# Altium schematic sheet 1-MAIN.SchDoc
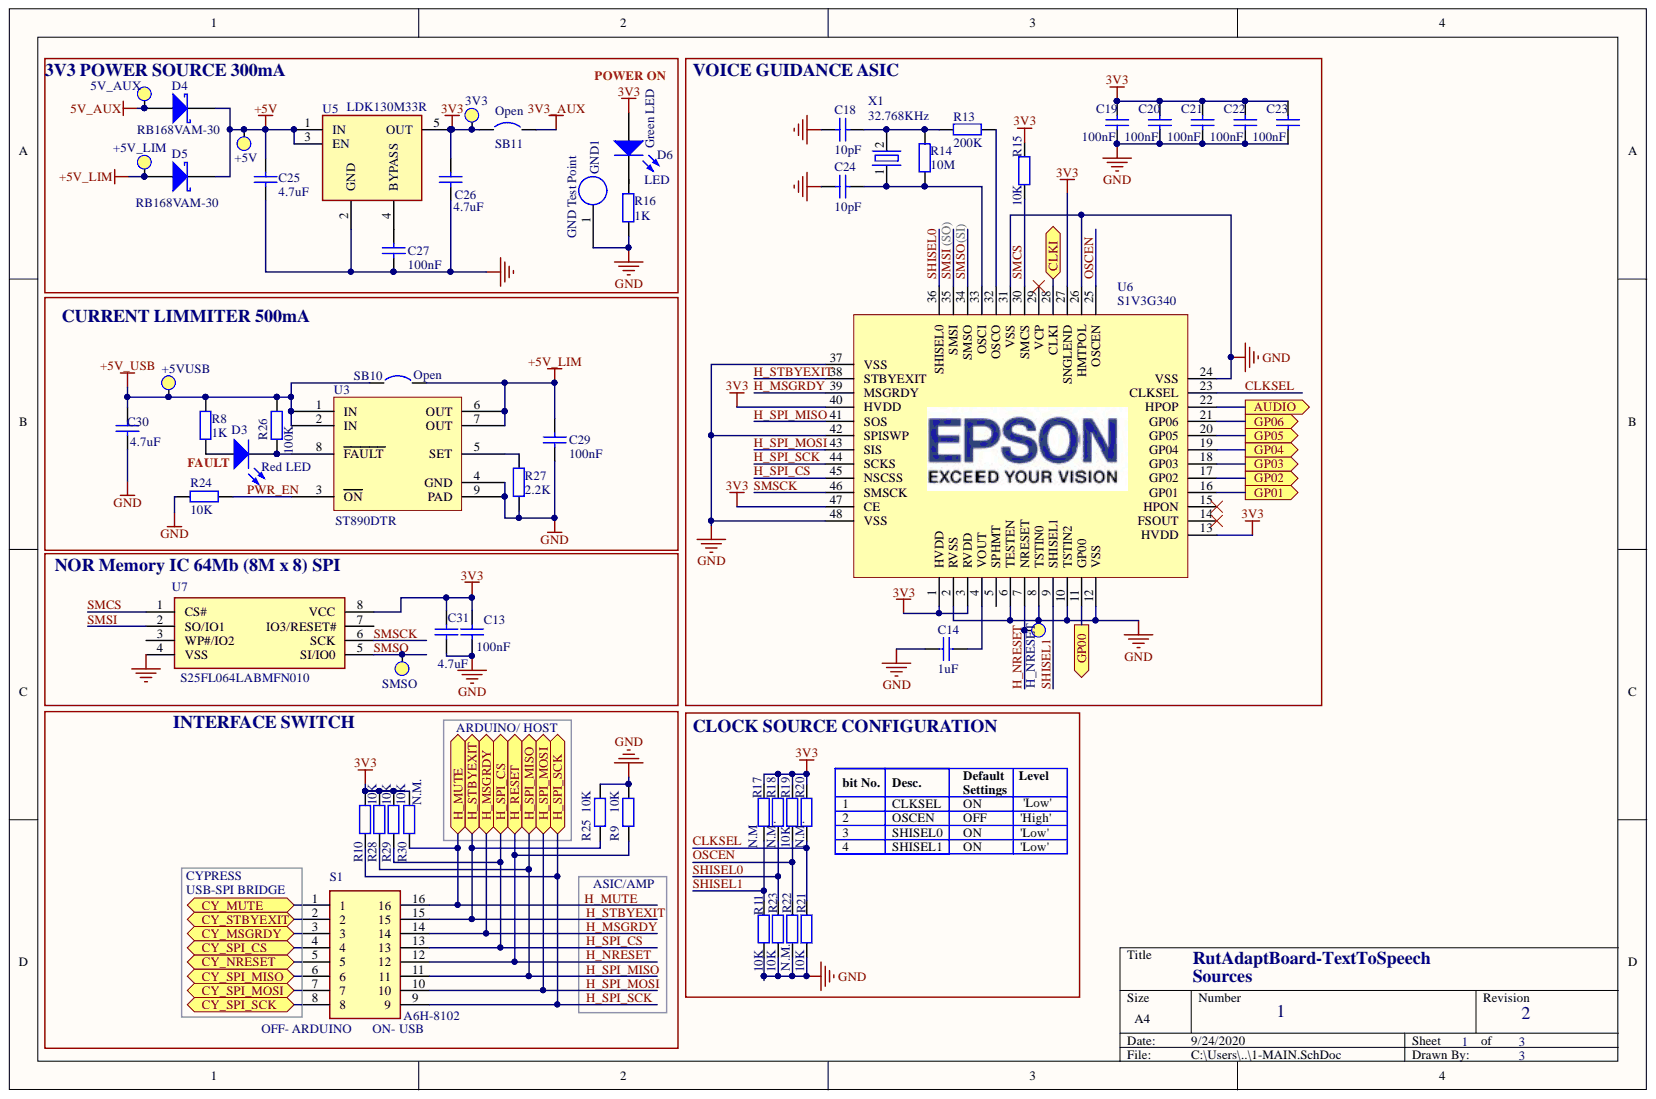
[diagram p0: 1-MAIN.SchDoc]

## Parts
3V3  Test_Point  [TEST_POINT]  (no BOM)
5V_AUX  Test_Point  [TEST_POINT]  (no BOM)
+5V  Test_Point  [TEST_POINT]  (no BOM)
+5V_LIM  Test_Point  [TEST_POINT]  (no BOM)
+5VUSB  Test_Point  [TEST_POINT]  (no BOM)
C13  value 100nF  [C0402]  (hidden comment: Cap Semi)
C14  value 1uF  [C0402]  (hidden comment: Cap Semi)
C18  value 10pF  [C0402]  (hidden comment: Cap Semi)
C19  value 100nF  [C0402]  (hidden comment: Cap Semi)
C20  value 100nF  [C0402]  (hidden comment: Cap Semi)
C21  value 100nF  [C0402]  (hidden comment: Cap Semi)
C22  value 100nF  [C0402]  (hidden comment: Cap Semi)
C23  value 100nF  [C0402]  (hidden comment: Cap Semi)
C24  value 10pF  [C0402]  (hidden comment: Cap Semi)
C25  value 4.7uF  [C0402]  (hidden comment: Cap Semi)
C26  value 4.7uF  [C0402]  (hidden comment: Cap Semi)
C27  value 100nF  [C0402]  (hidden comment: Cap Semi)
C29  value 100nF  [C0402]  (hidden comment: Cap Semi)
C30  value 4.7uF  [C0402]  (hidden comment: Cap Semi)
C31  value 4.7uF  [C0402]  (hidden comment: Cap Semi)
D3  Red LED  [PICOLED]
D4  RB168VAM-30  [SOD323]
D5  RB168VAM-30  [SOD323]
D6  LED  [PICOLED]
GND1  GND Test Point  [5019_keystone]
H_NRESET  Test_Point  [TEST_POINT]  (no BOM)
R8  value 1K  [R0402]  (hidden comment: Res2)
R9  value 10K  [R0402]  (hidden comment: Res2)
R10  value 10K  [R0402]  (hidden comment: Res2)
R11  value 10K  [R0402]  (hidden comment: Res2)
R13  value 200K  [R0402]  (hidden comment: Res2)
R14  value 10M  [R0402]  (hidden comment: Res2)
R15  value 10K  [R0402]  (hidden comment: Res2)
R16  value 1K  [R0402]  (hidden comment: Res2)
R17  value N.M  [R0402 N.M.]  (hidden comment: Res2)  (no BOM)
R18  value N.M.  [R0402 N.M.]  (hidden comment: Res2)  (no BOM)
R19  value 10K  [R0402]  (hidden comment: Res2)
R20  value N.M.  [R0402 N.M.]  (hidden comment: Res2)  (no BOM)
R21  value N.M.  [R0402]  (hidden comment: Res2)
R22  value 10K  [R0402 N.M.]  (hidden comment: Res2)  (no BOM)
R23  value 10K  [R0402]  (hidden comment: Res2)
R24  value 10K  [R0402]  (hidden comment: Res2)
R25  value 10K  [R0402]  (hidden comment: Res2)
R26  value 100K  [R0402]  (hidden comment: Res2)
R27  value 2.2K  [R0402]  (hidden comment: Res2)
R28  value 10K  [R0402]  (hidden comment: Res2)
R29  value 10K  [R0402]  (hidden comment: Res2)
R30  value N.M.  [R0402 N.M.]  (hidden comment: Res2)  (no BOM)
S1  A6H-8102  [SOIC127P670X195-16N]
SB10  Open  [SB_0402 - open]  (no BOM)
SB11  Open  [SB_0402 - open]  (no BOM)
SMSO  Test_Point  [TEST_POINT]  (no BOM)
U3  ST890DTR  [DFN8L_3x3]
U5  LDK130M33R  [SOT23-5L]
U6  S1V3G340  [LQFP12-48]  (no BOM)
U7  S25FL064LABMFN010  Specification: 64Mb (8M x 8)  [SOIC127P800X216-8N]
X1  32.768KHz  [XTAL_3215]

## Ports
AUDIO, CLKI, CY_MSGRDY, CY_MUTE, CY_NRESET, CY_SPI_CS, CY_SPI_MISO, CY_SPI_MOSI, CY_SPI_SCK, CY_STBYEXIT, GP00, GP01, GP02, GP03, GP04, GP05, GP06, H_MSGRDY, H_MUTE, H_RESET, H_SPI_CS, H_SPI_MISO, H_SPI_MOSI, H_SPI_SCK, H_STBYEXIT

## Nets
3V3: 3V3.0 C13.2 C19.2 C20.2 C21.2 C22.2 C23.2 C26.1 C31.1 D6.1 R10.1 R15.1 R17.1 R18.1 R19.1 R20.1 R28.1 R29.1 R30.1 SB11.1 U5.5(OUT) U6.1(HVDD) U6.3(RVDD) U6.13(HVDD) U6.27(SNGLEND) U6.40(HVDD) U6.47(CE) U7.8(VCC)
3V3_AUX: SB11.2
5V_AUX: 5V_AUX.0 D4.1
+5V: +5V.0 C25.1 D4.2 D5.2 U5.1(IN) U5.3(EN)
+5V_LIM: +5V_LIM.0 C29.2 D5.1 SB10.2 U3.6(OUT) U3.7(OUT)
+5V_USB: +5VUSB.0 C30.1 R8.2 R26.1 SB10.1 U3.1(IN) U3.2(IN)
GND: C13.1 C14.2 C18.1 C19.1 C20.1 C21.1 C22.1 C23.1 C24.1 C25.2 C26.2 C27.1 C29.1 C30.2 C31.2 GND1.1 R9.1 R11.1 R16.1 R21.1 R22.1 R23.1 R24.1 R25.1 R27.2 U3.4(GND) U3.9(PAD) U5.2(GND) U6.2(RVSS) U6.6(TESTEN) U6.8(TSTIN0) U6.10(TSTIN2) U6.12(VSS) U6.24(VSS) U6.26(HMTPOL) U6.31(VSS) U6.37(VSS) U6.42(SPISWP) U6.48(VSS) U7.4(VSS)
AUDIO: U6.22(HPOP) ; port AUDIO
CLKI: U6.28(CLKI) ; port CLKI
CLKSEL: R20.2 R21.2 U6.23(CLKSEL)
CY_MSGRDY: S1.3 ; port CY_MSGRDY
CY_MUTE: S1.1 ; port CY_MUTE
CY_NRESET: S1.5 ; port CY_NRESET
CY_SPI_CS: S1.4 ; port CY_SPI_CS
CY_SPI_MISO: S1.6 ; port CY_SPI_MISO
CY_SPI_MOSI: S1.7 ; port CY_SPI_MOSI
CY_SPI_SCK: S1.8 ; port CY_SPI_SCK
CY_STBYEXIT: S1.2 ; port CY_STBYEXIT
GP00: U6.11(GP00) ; port GP00
GP01: U6.16(GP01) ; port GP01
GP02: U6.17(GP02) ; port GP02
GP03: U6.18(GP03) ; port GP03
GP04: U6.19(GP04) ; port GP04
GP05: U6.20(GP05) ; port GP05
GP06: U6.21(GP06) ; port GP06
H_MSGRDY: S1.14 U6.39(MSGRDY) ; port H_MSGRDY
H_MUTE: R30.2 S1.16 ; port H_MUTE
H_NRESET: H_NRESET.0 R9.2 S1.12 U6.7(NRESET) ; port H_RESET
H_SPI_CS: R29.2 S1.13 U6.45(NSCSS) ; port H_SPI_CS
H_SPI_MISO: R28.2 S1.11 U6.41(SOS) ; port H_SPI_MISO
H_SPI_MOSI: S1.10 U6.43(SIS) ; port H_SPI_MOSI
H_SPI_SCK: R10.2 S1.9 U6.44(SCKS) ; port H_SPI_SCK
H_STBYEXIT: R25.2 S1.15 U6.38(STBYEXIT) ; port H_STBYEXIT
OSCEN: R19.2 R22.2 U6.25(OSCEN)
PWR_EN: R24.2 U3.3(ON)
SHISEL0: R18.2 R23.2 U6.36(SHISEL0)
SHISEL1: R11.2 R17.2 U6.9(SHISEL1)
SMCS: R15.2 U6.30(SMCS) U7.1(CS#)
SMSCK: U6.46(SMSCK) U7.6(SCK)
SMSI: U6.35(SMSI) U7.2(SO/IO1)
SMSO: SMSO.0 U6.34(SMSO) U7.5(SI/IO0)
NetC14_1: C14.1 U6.4(VOUT)
NetC18_2: C18.2 R13.2 R14.2 X1.2
NetC24_2: C24.2 R14.1 U6.33(OSCI) X1.1
NetC27_2: C27.2 U5.4(BYPASS)
NetD3_1: D3.1 R8.1
NetD3_2: D3.2 R26.2 U3.8(FAULT)
NetR13_1: R13.1 U6.32(OSCO)
NetR27_1: R27.1 U3.5(SET)

Not connected: D6 2; R16 2; U6 5(SPHMT) 14(FSOUT) 15(HPON) 29(VCP); U7 3(WP#/IO2) 7(IO3/RESET#)

## Library data of the parts
3V3 5V_AUX +5V +5V_LIM +5VUSB H_NRESET SMSO = Test_Point: Test Point; Library Name: Test Point.SchLib
C13 C14 C19-C23 C27 C29 = Cap Semi: Capacitor (Semiconductor SIM Model); Manufacturer: Samsung EM; Manufacturer Part No.: CL05B104KO5NNNC; Part Description: Ceramic Capacitor; Specification: X7R, 16V, 10%; Component Kind: Standard; Library Name: Miscellaneous Devices.IntLib; Link: https://www.rutronik24.com/product/samsung+em/cl05b104ko5nnnc/4748662.html; Signal Integrity: Cap Semi; Simulation: CAP; Supplier: Rutronik; Supplier part number: KKK21419
C18 C24 = Cap Semi: Capacitor (Semiconductor SIM Model); Manufacturer: Yageo; Manufacturer Part No.: CC0402JRNPO9BN120; Part Description: Ceramic Capacitor; Specification: NP0, 50V, 5%; Component Kind: Standard; Library Name: Miscellaneous Devices.IntLib; Link: https://www.rutronik24.com/search-result/nojs:1337/qs:CC0402JRNPO9BN120/reset:0; Signal Integrity: Cap Semi; Simulation: CAP; Supplier: Rutronik; Supplier part number: KKK15647
C25 C26 C30 C31 = Cap Semi: Capacitor (Semiconductor SIM Model); Manufacturer: Samsung EM; Manufacturer Part No.: CL05A475MQ5NRNC; Part Description: Ceramic Capacitor; Specification: X5R, 6.3V, 20%; Component Kind: Standard; Library Name: Miscellaneous Devices.IntLib; Link: https://www.rutronik24.com/product/samsung+em/cl05a475mq5nrnc/4333694.html; Signal Integrity: Cap Semi; Simulation: CAP; Supplier: Rutronik; Supplier part number: KKS1042
D3 = LED2: Typical SMD LED; Manufacturer: Vishay; Manufacturer Part No.: VLMS1500-GS08; Specification: Red, 5mA; Value: VLMS1500-GS08; Component Kind: Standard; DigiKey link: https://www.digikey.com/product-detail/en/vishay-semiconductor-opto-division/VLMS1500-GS08/VLMS1500-GS08CT-ND/3504675; Library Name: Miscellaneous Devices.IntLib; Link: https://www.rutronik24.com/search-result/qs:VLMS1500-GS08/reset:0; Part Description: Typical SMD LED; Simulation: LED2; Supplier: Rutronik; Supplier part number: LED24641
D4 D5 = D Schottky: Schottky Diode; Manufacturer: ROHM; Manufacturer Part No.: RSX201LAM30TR; Part Description: Low VF Schottky Barrier Rectifier; Specification: 30V, 2A; Value: RSX201LAM30TR; Component Kind: Standard; DigiKey link: https://www.digikey.com/product-detail/en/rohm-semiconductor/RSX201LAM30TR/RSX201LAM30TRCT-ND/7793362; Library Name: Miscellaneous Devices.IntLib; Link: https://www.rutronik24.com/search-result/qs:RB168VAM150/reset:0; Signal Integrity: D Schottky; Simulation: SKYDIODE; Supplier: Rutronik; Supplier part number: RB168VAM150TR
D6 = LED2: Typical SMD LED; Manufacturer: OSRAM; Manufacturer Part No.: LT QH9G-Q2OO-25-2Z4Y; Specification: Green, 5mA; Value: LT QH9G-Q2OO-25-2Z4Y; Component Kind: Standard; DigiKey link: https://www.digikey.com/product-detail/en/kingbright/APHHS1005CGCK/754-1101-1-ND/1747818; Library Name: Miscellaneous Devices.IntLib; Link: https://www.rutronik24.com/product/osram/ltqh9g-q2oo-25-2z4y-5-r18/7461152.html; Part Description: Typical SMD LED; Simulation: LED2; Supplier: Rutronik; Supplier part number: LED22816
GND1 = 5019: SMD Test Point; Manufacturer: Keystone Electrincs; Part Description: SMT Test Point; Specification: 3.81*2.03; Value: 5019; Component Kind: Standard; DigiKey link: https://www.digikey.com/product-detail/en/keystone-electronics/5019/36-5019CT-ND/3907344; Library Name: test_point.SchLib; Link: https://www.rutronik24.com/product/keystone/pn5019/7028403.html; Manufacturer Part No.: 5019; Supplier: Rutronik; Supplier part number: BAT4950
R8 R16 = Res2: Manufacturer: Yageo; Manufacturer Part No.: RC0402JR-071KL; Part Description: Thick Film Resistor; Specification: 5% 0,063W; Component Kind: Standard; Library Name: Miscellaneous Devices.IntLib; Link: https://www.rutronik24.com/search-result/nojs:1337/qs:RC0402JR-071KL/reset:0; Signal Integrity: Res; Simulation: RESISTOR; Supplier: Rutronik; Supplier part number: WRC33833
R9-R11 R13-R15 R17-R20 R22 R23 R25 R28-R30 = Res2: Manufacturer: ASJ; Manufacturer Part No.: CR10-103-JK; Part Description: Thick Film Resistor; Specification: 5% 0,063W; Library Name: Miscellaneous Devices.IntLib; Link: https://www.rutronik24.com/product/asj/cr10-103-jk/54295.html; Signal Integrity: Res; Simulation: RESISTOR; Supplier: Rutronik; Supplier part number: WRC40976
  R9-R11 R19 R23 R25 R28 R29: Component Kind: Standard; DigiKey link: https://www.digikey.com/product-detail/en/susumu/RR0510P-103-D/RR05P10-0KDCT-ND/432960
  R13-R15: Component Kind: Standard
  R17 R18 R20 R22: DigiKey link: https://www.digikey.com/product-detail/en/susumu/RR0510P-103-D/RR05P10-0KDCT-ND/432960
R21 = Res2: Manufacturer: ASJ; Manufacturer Part No.: CR10-103-JK; Part Description: Thick Film Resistor; Specification: 5% 0,063W; Value: N.M.; Component Kind: Standard; DigiKey link: https://www.digikey.com/product-detail/en/susumu/RR0510P-103-D/RR05P10-0KDCT-ND/432960; Library Name: Miscellaneous Devices.IntLib; Link: https://www.rutronik24.com/product/asj/cr10-103-jk/54295.html; Signal Integrity: Res; Simulation: RESISTOR; Supplier: Rutronik; Supplier part number: WRC40976
R24 = Res2: Manufacturer: Yageo; Manufacturer Part No.: RC0402JR-0710KL; Part Description: Thick Film Resistor; Specification: 5% 0,063W; Component Kind: Standard; Library Name: Miscellaneous Devices.IntLib; Link: https://www.rutronik24.com/search-result/nojs:1337/qs:RC0402JR-0710KL/reset:0; Signal Integrity: Res; Simulation: RESISTOR; Supplier: Rutronik; Supplier part number: WRC33854
R26 = Res2: Manufacturer: Yageo; Manufacturer Part No.: RC0402JR-07100KL; Part Description: Thick Film Resistor; Specification: 5% 0,063W; Component Kind: Standard; Library Name: Miscellaneous Devices.IntLib; Link: https://www.rutronik24.com/search-result/nojs:1337/qs:RC0402JR-07100KL/reset:0; Signal Integrity: Res; Simulation: RESISTOR; Supplier: Rutronik; Supplier part number: WRC33855
R27 = Res2: Manufacturer: Yageo; Manufacturer Part No.: RC0402JR-072K2L; Part Description: Thick Film Resistor; Specification: 5% 0,063W; Component Kind: Standard; Library Name: Miscellaneous Devices.IntLib; Link: https://www.rutronik24.com/search-result/nojs:1337/qs:RC0402JR-072K2L/reset:0; Signal Integrity: Res; Simulation: RESISTOR; Supplier: Rutronik; Supplier part number: WRC33840
S1 = A6H-8101: Switch; Manufacturer: Omron; Part Description: SWITCH SLIDE DIP SPST 25MA 24V; Specification: 8 pos. 2,54mm; Component Kind: Standard; DigiKey link: https://www.digikey.lt/product-detail/en/omron-electronics-inc-emc-div/A6H-8102-P/Z12738CT-ND/9836959; Link: https://www.rutronik24.com/product/omron/a6h-8102/12406312.html; Manufacturer Part No.: A6H-8102; Supplier: Rutronik; Supplier part number: A6H-8102; Value: A6H-8102
SB10 SB11 = Circuit Breaker_close: Circuit Breaker; Manufacturer: Yageo; Manufacturer Part No.: RC04020R; Part Description: JUMPER; Specification: 1/16W; Value: 0R; DigiKey link: https://www.digikey.com/product-detail/en/stackpole-electronics-inc/RMCF0402ZT0R00/RMCF0402ZT0R00CT-ND/1942941; Library Name: MB1167.SCHLIB; Link: https://www.rutronik24.com/product/yageo/ac0402fr-070rl/1082692.html; Revision: July-2002: Re-released for DXP Platform.; Supplier: Rutronik; Supplier part number: WRC52534
U3 = ST890CDR: 1.2 A current limited high-side power switch with thermal shutdown; Manufacturer: STMicroelectronics; Specification: 2.7 .. 5.5V, 1.2A; Component Kind: Standard; DigiKey link: https://www.digikey.com/product-detail/en/stmicroelectronics/ST890DTR/497-6833-6-ND/1866988; Library Name: DevKit_STM32L5.SCHLIB; Link: https://www.st.com/en/switches-and-multiplexers/st890.html; Manufacturer Part No.: ST890DTR; Part Description: 1.2 A current limited high-side power switch with thermal shutdown; Supplier: Rutronik; Value: ST890DTR
U5 = LDK130C33R: 300 mA low quiescent current very low noise LDO  (automotive for SOT23-5L package); Manufacturer: STMicroelectronics; Specification: 3.3V, 300mA; Component Kind: Standard; DigiKey link: https://www.digikey.com/product-detail/en/stmicroelectronics/LDK130M33R/497-13706-1-ND/4090327; Library Name: LDK130C33R .SchLib; Link: https://www.rutronik24.com/product/st/ldk130m33r/1536595.html; Manufacturer Part No.: LDK130M33R; Part Description: 300 mA low quiescent current very low noise LDO; Supplier: Rutronik; Supplier part number: ICSPR9313; Value: LDK130M33R
U6 = S1V3G340: Manufacturer: Epson Electronics; Manufacturer Part No.: S1V3G340F01A900; Part Description: Text-to-Speech IC; Value: S1V3G340F01A900; Library Name: Schlib1.SchLib; Link: https://www.rutronik24.com/product/epson/s1v3g340+text-to-speech+ic++++++rohsconf/13713938.html; Supplier: Rutronik; Supplier part number: ICPER6856
U7 = S25FL064LABMFN010: Integrated Circuit; Manufacturer: Cypress Semiconductor; Part Description: IC FLASH 64M SPI 108MHZ; Component Kind: Standard; DigiKey link: https://www.digikey.com/product-detail/en/cypress-semiconductor-corp/S25FL064LABMFN010/S25FL064LABMFN010-ND/7362837; Link: https://www.digikey.com/product-detail/en/cypress-semiconductor-corp/S25FL064LABMFN010/2015-S25FL064LABMFN010-ND/9955072; Manufacturer Part No.: S25FL064LABMFN010; Supplier: Rutronik; Supplier part number: S25FL064LABMFN010; Value: -
X1 = XTL-000011-01: Manufacturer: Epson Electronics; Manufacturer Part No.: X1A000141000312; Part Description: XTAL 3.2x1.5mm; Specification: 32.768 kHz, 12.5pF, 20ppm; Value: X1A000141000312; Component Kind: Standard; DigiKey link: https://www.digikey.com/product-detail/en/epson/FC-135R-32-7680KA-A3/SER4071CT-ND/5604227; Link: https://www.rutronik24.com/search-result/nojs:1337/qs:X1A000141000312/reset:0; Supplier: Rutronik; Supplier part number: QUARZ6278; Library Name: RUT_L865_Project_1.SCHLIB
